# Altium schematic sheet 01_TOP_LEVEL.SchDoc(01_TOP_LEVEL)
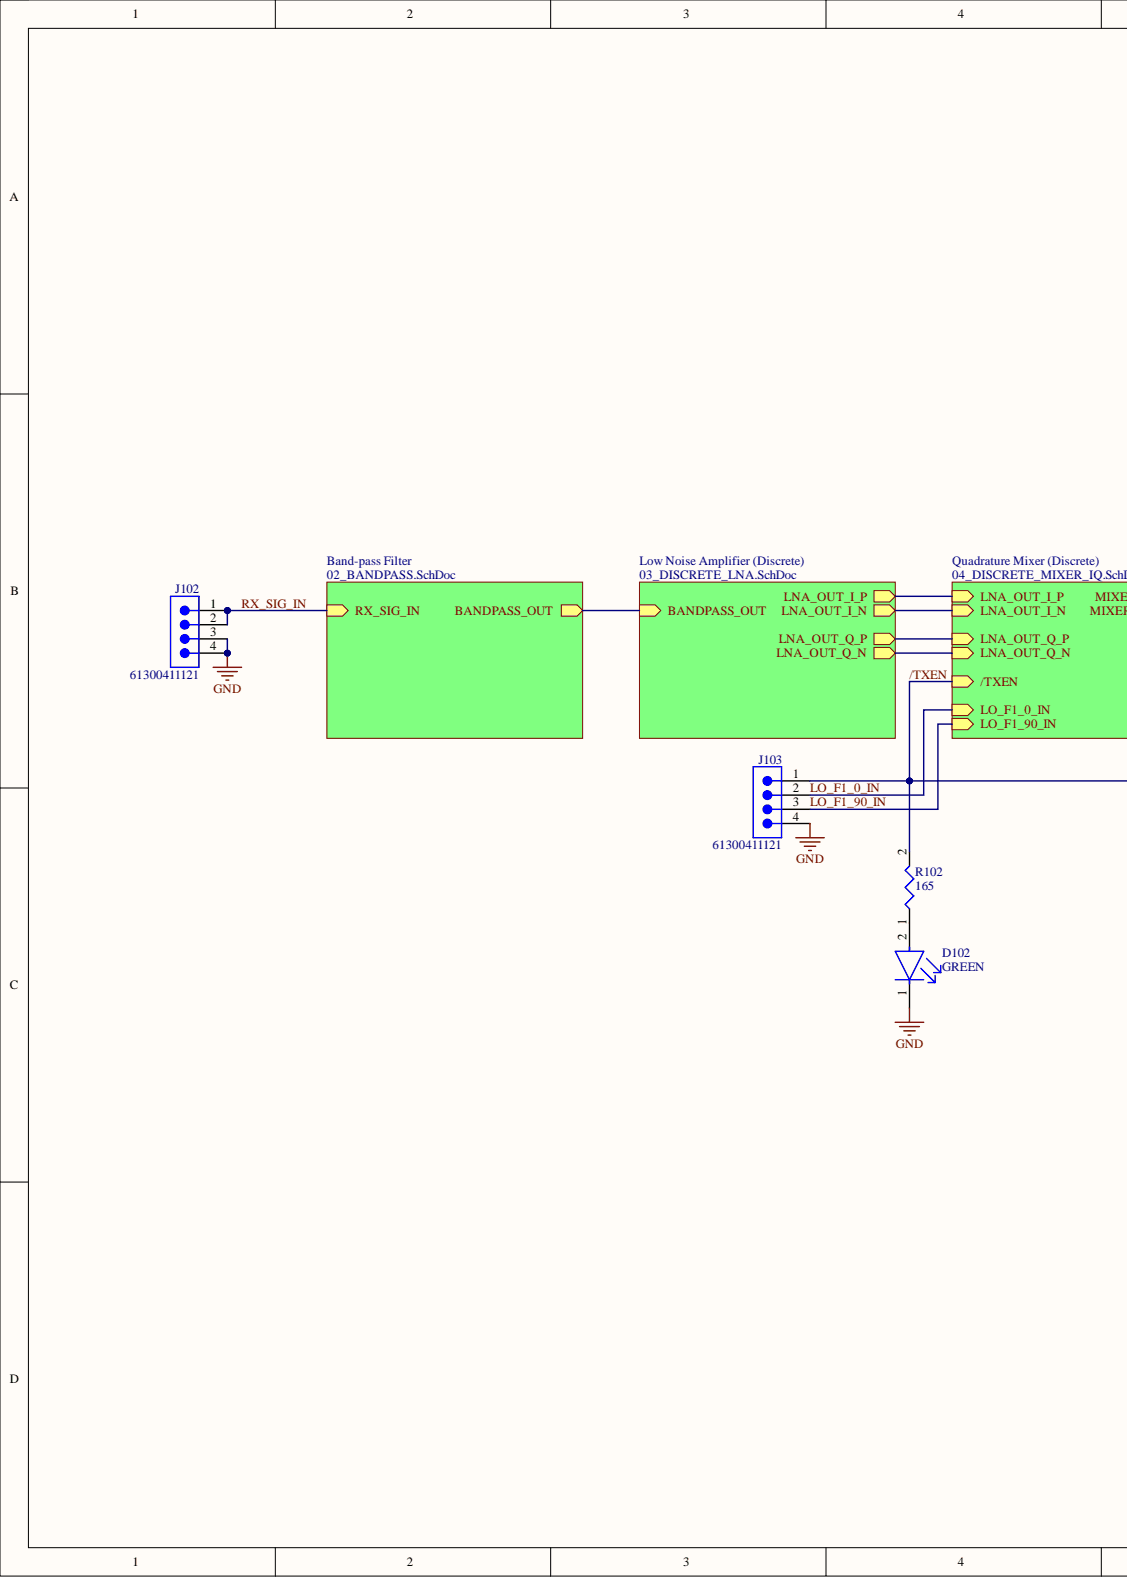
[diagram p0: 01_TOP_LEVEL.SchDoc, left]
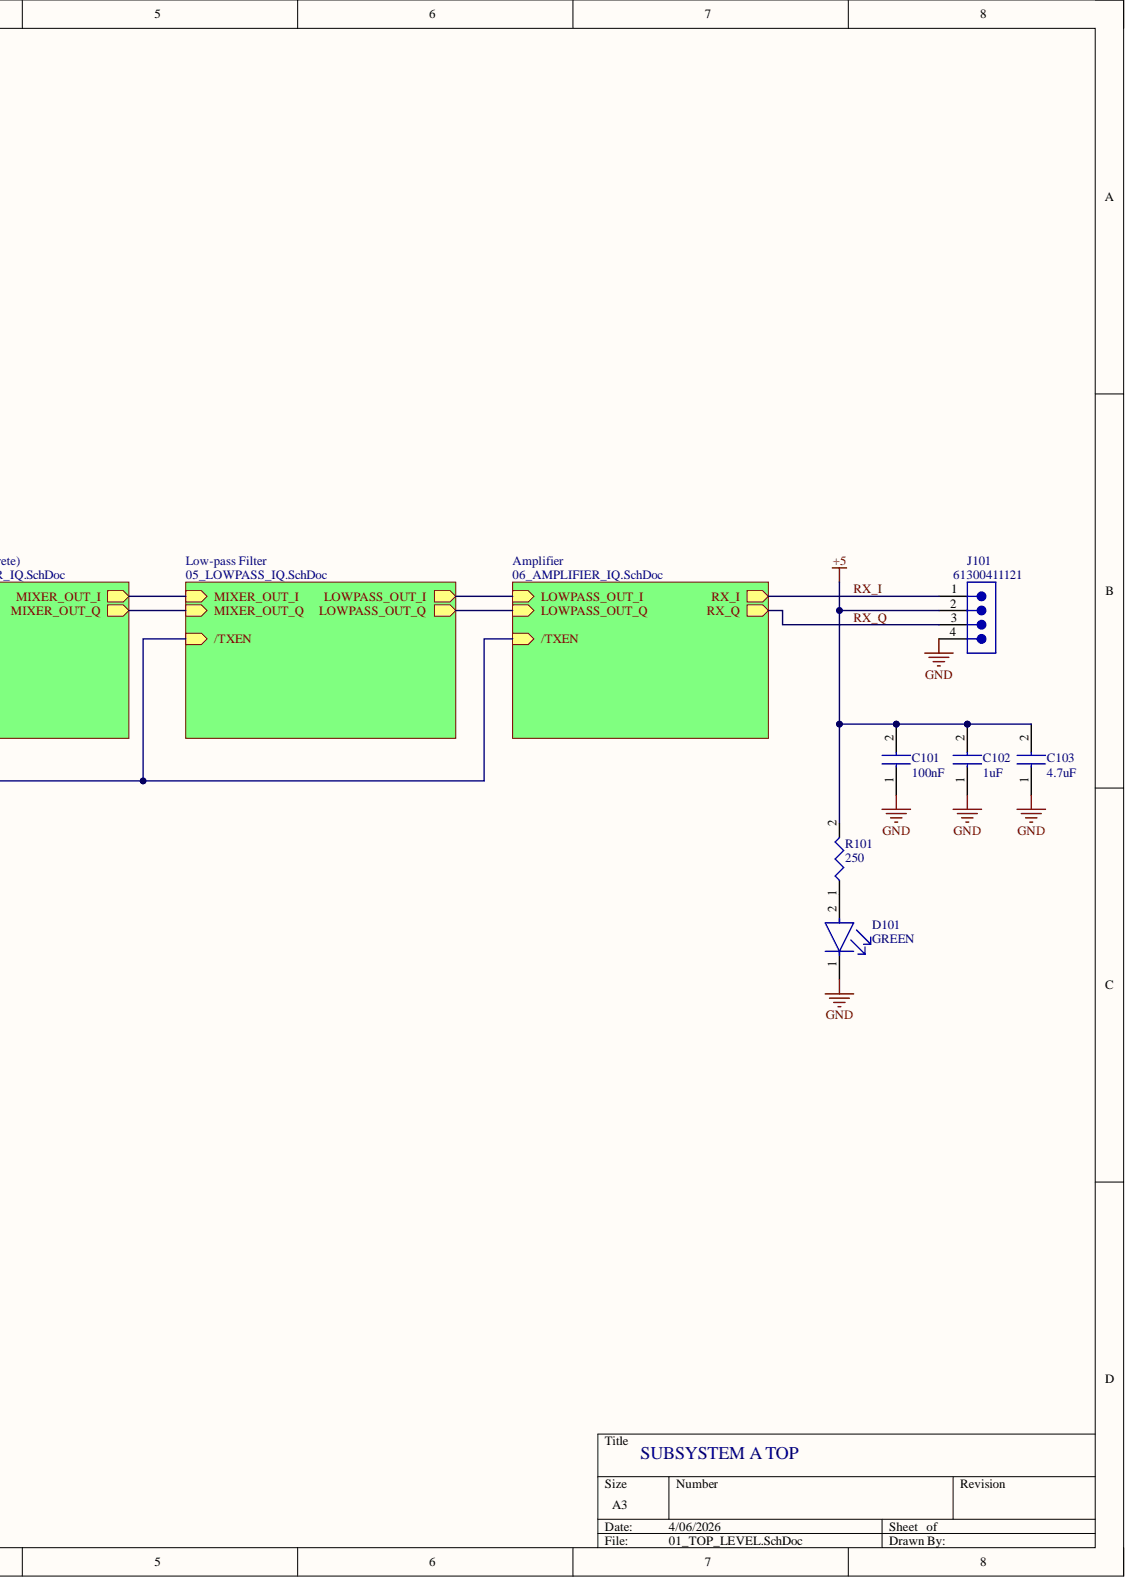
[diagram p1: 01_TOP_LEVEL.SchDoc, right]

## Parts
C101  100nF
C102  1uF
C103  4.7uF
D101  GREEN
D102  GREEN
J101  61300411121
J102  61300411121
J103  61300411121
R101  250
R102  165

## Nets
+5: C101.2 C102.2 C103.2 J101.2 R101.2
GND: C101.1 C102.1 C103.1 D101.1 D102.1 J101.4 J102.3 J102.4 J103.4
/TXEN: J103.1 R102.2
LO_F1_0_IN: J103.2
LO_F1_90_IN: J103.3
RX_I: J101.1
RX_Q: J101.3
RX_SIG_IN: J102.1 J102.2
NetD101_2: D101.2 R101.1
NetD102_2: D102.2 R102.1
BANDPASS_OUT:
LNA_OUT_I_N:
LNA_OUT_I_P:
LNA_OUT_Q_N:
LNA_OUT_Q_P:
LOWPASS_OUT_I:
LOWPASS_OUT_Q:
MIXER_OUT_I:
MIXER_OUT_Q:

## Library data of the parts
C101-C103 = CAP_0805: Capacitor SMD 0805; Component Kind: Standard; Height: 0.94mm; Library Name: Passive_Components.SchLib
D101 D102 = CMP-1674-00002-6: LED GREEN CLEAR SMD; Dominant Wavelength: 569nm; Forward Current: 30mA; Forward Voltage: 2.1V; Lens Color: Colorless; Lens Style: Diffused; Luminous Intensity: 6mcd; Number of Elements: 1; Power Dissipation: 100mW; Reverse Voltage: 5V; Test Current: 10mA; Viewing Angle: 130°; Wavelength: 565nm; Case Code (Imperial): 0805; Case Code (Metric): 2012; Case/Package: 0805; Color: Green; Component Kind: Standard; ComponentLink1Description: DigiKey; ComponentLink1URL: https://www.digikey.ca/en/products/detail/liteon/LTST-C170GKT/269226; Depth: 1.25mm; Height: 1.1mm; Illumination Color: Green; Lead Free: Lead Free; Length: 2mm; Lens Transparency: Clear; Library Name: Altium Content Vault; Max Operating Temperature: 85°C; Min Operating Temperature: -55°C; Mount: Surface Mount; Number of LEDs: 1; Number of Pins: 2; Package Quantity: 1; Packaging: Tape and Reel; Power Rating: 100mW; Radiation Hardening: No; Voltage: 5V; Width: 1.25mm
J101-J103 = CMP-1502-01064-4: THT Vertical Pin Header WR-PHD, Pitch 2.54 mm, Single Row, 4 pins; Connector Type: Male Pin; Contact Resistance: 20mΩ; Current Rating: 3A; Gender: Male; Number of Contacts: 4; Operating Supply Voltage: 250V; Pitch: 2.54mm; Color: Black; Component Kind: Standard; ComponentLink1Description: DigiKey; ComponentLink1URL: https://www.digikey.com/en/products/detail/w%C3%BCrth-elektronik/61300411121/4846827?s=N4IgTCBcDaIGwEYDMAGFAWBWwJAXQF8g; Contact Material: Gold; Contact Mating Length: 5.9944mm; Contact Plating: Gold; Height: 8.89mm; Lead Free: Lead Free; Lead Pitch: 2.54mm; Library Name: Altium Content Vault; Max Operating Temperature: 105°C; Min Operating Temperature: -40°C; Mount: Through Hole; Number of Pins: 4; Number of Positions: 4; Number of Rows: 1; Orientation: Vertical; Packaging: Bulk; RoHS: Compliant; Termination: Solder; Voltage Rating: 250V
R101 R102 = RES_0805: Resistor SMD 0805; Component Kind: Standard; Library Name: Passive_Components.SchLib
